# Altium schematic sheet STSPIN32.SchDoc
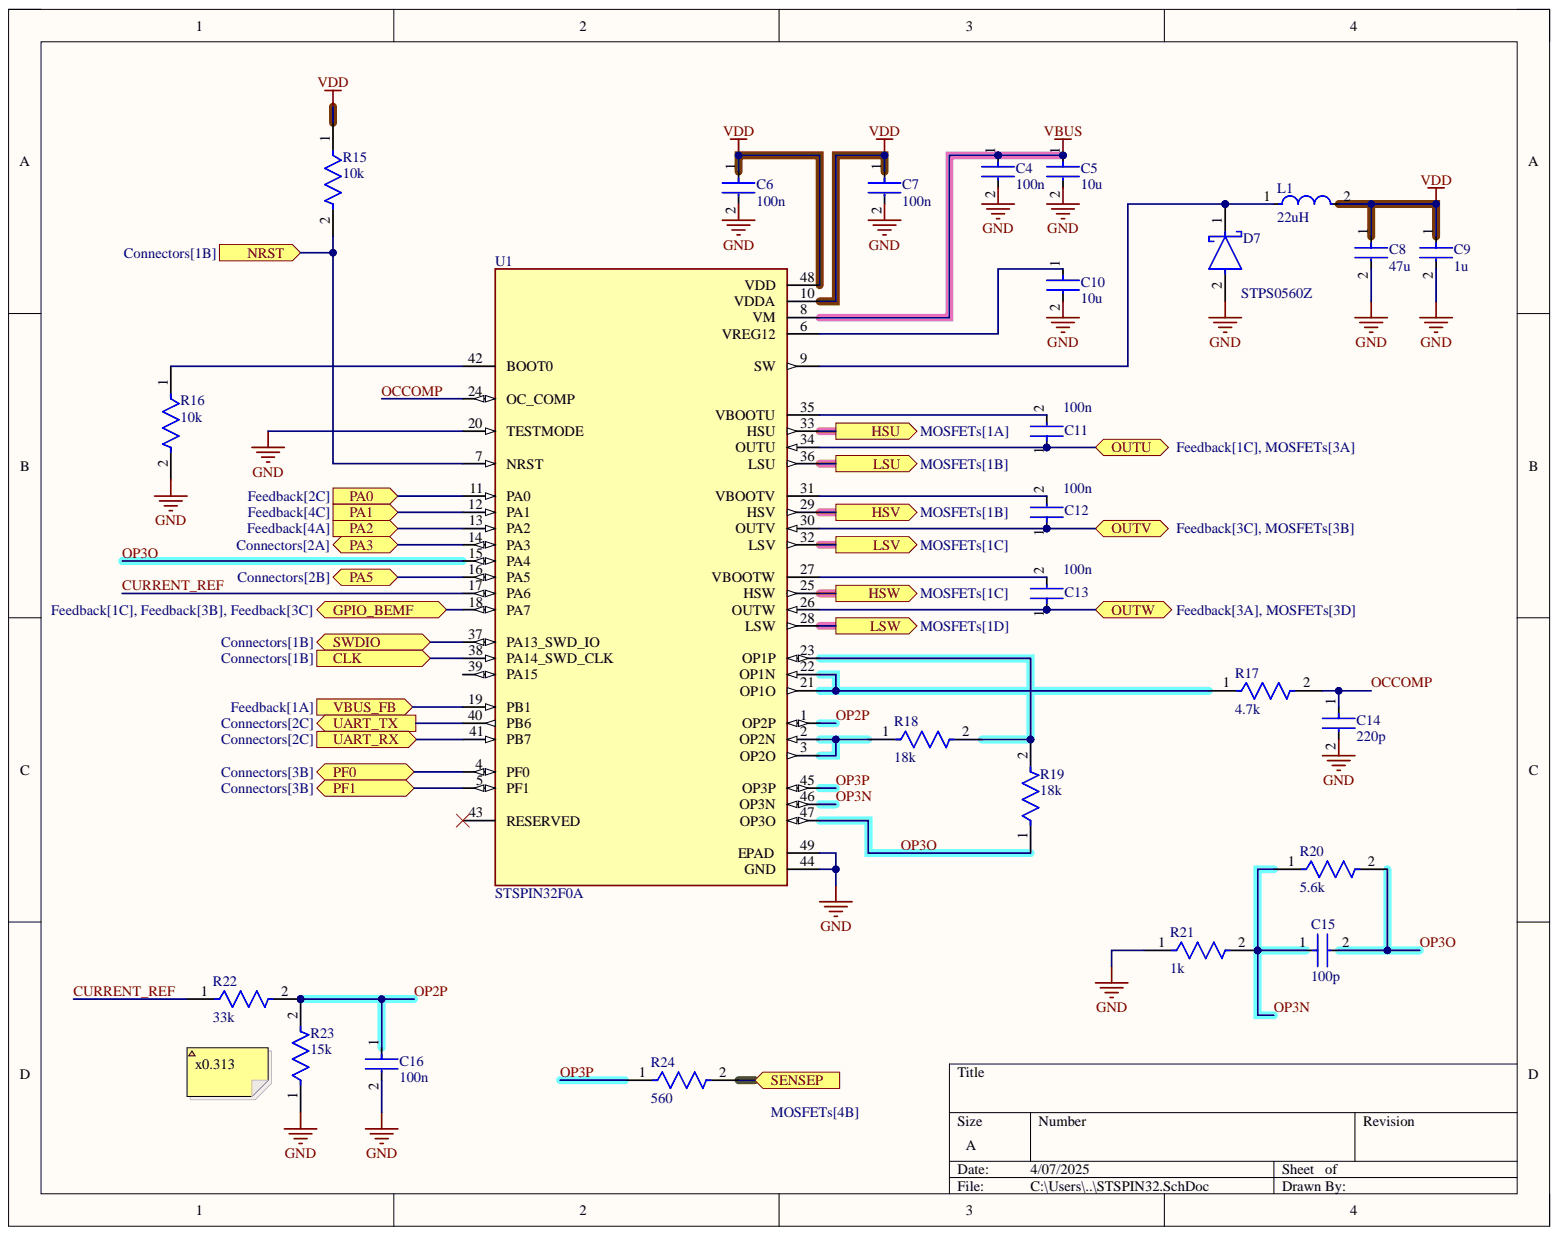
[diagram p0: STSPIN32.SchDoc]

## Parts
C4  100n  [FP-GRM188-0_1-e0_2_0_55-IPC_A]
C5  10u  [FP-GRM188-0_1-e0_2_0_55-IPC_A]
C6  100n  [FP-GRM188-0_1-e0_2_0_55-IPC_A]
C7  100n  [FP-GRM188-0_1-e0_2_0_55-IPC_A]
C8  47u  [FP-GRM188-0_1-e0_2_0_55-IPC_A]
C9  1u  [FP-GRM188-0_1-e0_2_0_55-IPC_A]
C10  10u  [FP-GRM188-0_1-e0_2_0_55-IPC_A]
C11  100n  [FP-GRM188-0_1-e0_2_0_55-IPC_A]
C12  100n  [FP-GRM188-0_1-e0_2_0_55-IPC_A]
C13  100n  [FP-GRM188-0_1-e0_2_0_55-IPC_A]
C14  220p  [FP-GRM188-0_1-e0_2_0_55-IPC_A]
C15  100p  [FP-GRM188-0_1-e0_2_0_55-IPC_A]
C16  100n  [FP-GRM188-0_1-e0_2_0_55-IPC_A]
D7  STPS0560Z  [FP-SOD-123-MFG]
L1  22uH  [FP-LQM18NN_00-IPC_C]
R15  10k  [FP-CRCW0603-e3-IPC_A]
R16  10k  [FP-CRCW0603-e3-IPC_A]
R17  4.7k  [FP-CRCW0603-e3-IPC_A]
R18  18k  [FP-CRCW0603-e3-IPC_A]
R19  18k  [FP-CRCW0603-e3-IPC_A]
R20  5.6k  [FP-CRCW0603-e3-IPC_A]
R21  1k  [FP-CRCW0603-e3-IPC_A]
R22  33k  [FP-CRCW0603-e3-IPC_A]
R23  15k  [FP-CRCW0603-e3-IPC_A]
R24  560  [FP-CRCW0603-e3-IPC_A]
U1  STSPIN32F0A  [IC_STSPIN32F0A]

## Ports
CLK, GPIO_BEMF, HSU, HSV, HSW, LSU, LSV, LSW, NRST, OUTU, OUTV, OUTW, PA0, PA1, PA2, PA3, PA5, PF0, PF1, SENSEP, SWDIO, UART_RX, UART_TX, VBUS_FB

## Nets
GND: C4.2 C5.2 C6.2 C7.2 C8.2 C9.2 C10.2 C14.2 C16.2 D7.2 R16.2 R21.1 R23.1 U1.20(TESTMODE) U1.44(GND) U1.49(EPAD)
VBUS: C4.1 C5.1 U1.8(VM)
VDD: C6.1 C7.1 C8.1 C9.1 L1.2 R15.1 U1.10(VDDA) U1.48(VDD)
CLK: U1.38(PA14_SWD_CLK) ; port CLK
CURRENT_REF: R22.1 U1.17(PA6)
GPIO_BEMF: U1.18(PA7) ; port GPIO_BEMF
HSU: U1.33(HSU) ; port HSU
HSV: U1.29(HSV) ; port HSV
HSW: U1.25(HSW) ; port HSW
LSU: U1.36(LSU) ; port LSU
LSV: U1.32(LSV) ; port LSV
LSW: U1.28(LSW) ; port LSW
NRST: R15.2 U1.7(NRST) ; port NRST
OCCOMP: C14.1 R17.2 U1.24(OC_COMP)
OP2P: C16.1 R22.2 R23.2 U1.1(OP2P)
OP3N: C15.1 R20.1 R21.2 U1.46(OP3N)
OP3O: C15.2 R19.1 R20.2 U1.15(PA4) U1.47(OP3O)
OP3P: R24.1 U1.45(OP3P)
OUTU: C11.1 U1.34(OUTU) ; port OUTU
OUTV: C12.1 U1.30(OUTV) ; port OUTV
OUTW: C13.1 U1.26(OUTW) ; port OUTW
PA0: U1.11(PA0) ; port PA0
PA1: U1.12(PA1) ; port PA1
PA2: U1.13(PA2) ; port PA2
PA3: U1.14(PA3) ; port PA3
PA5: U1.16(PA5) ; port PA5
PF0: U1.4(PF0) ; port PF0
PF1: U1.5(PF1) ; port PF1
SENSEP: R24.2 ; port SENSEP
SWDIO: U1.37(PA13_SWD_IO) ; port SWDIO
UART_RX: U1.41(PB7) ; port UART_RX
UART_TX: U1.40(PB6) ; port UART_TX
VBUS_FB: U1.19(PB1) ; port VBUS_FB
NetC10_1: C10.1 U1.6(VREG12)
NetC11_2: C11.2 U1.35(VBOOTU)
NetC12_2: C12.2 U1.31(VBOOTV)
NetC13_2: C13.2 U1.27(VBOOTW)
NetD7_1: D7.1 L1.1 U1.9(SW)
NetR16_1: R16.1 U1.42(BOOT0)
NetR17_1: R17.1 U1.21(OP1O) U1.22(OP1N)
NetR18_1: R18.1 U1.2(OP2N) U1.3(OP2O)
NetR18_2: R18.2 R19.2 U1.23(OP1P)

Not connected: U1 39(PA15) 43(RESERVED)

## Library data of the parts
C4-C16 = CMP-2006-02792-2: Chip Multilayer Ceramic Capacitors for General Purpose, 0603, 10uF, X5R, 15%, 20%, 6.3V; Capacitance: 10uF; Case Code (Imperial): 0603; Case Code (Metric): 1608; Case/Package: 0603; Component Kind: Standard; Depth: 0.8mm; Dielectric: X5R; Height: 0.8mm; Lead Free: Lead Free; Length: 1.6mm; Library Name: Altium Content Vault; Material: Ceramic; Max Operating Temperature: 85°C; Min Operating Temperature: -55°C; Mount: Surface Mount; Package Quantity: 4000; Packaging: Tape and Reel; Radiation Hardening: No; REACH SVHC: No SVHC; Termination: SMD/SMT; Thickness: 0.035inch; Tolerance: 20%; Voltage: 6.3V; Voltage Rating: 6.3V; Voltage Rating (DC): 6.3V; Width: 0.031inch
D7 = CMP-12187-000036-1: DIODE SCHOTTKY 60V 500MA SOD123; Additional Feature: FREE WHEELING DIODE; Diode Element Material: SILICON; Element Configuration: Single; Forward Voltage: 660mV; Max Forward Surge Current (Ifsm): 5.5A; Max Reverse Current: 50uA; Number of Elements: 1; Number of Terminals/Pins: 2; Package Body Material: Plastic; Polarity: Standard; Average Rectified Current: 500mA; Component Kind: Standard; Contact Plating: Tin; Current Rating: 500mA; Forward Current: 500mA; Height: 1.35mm; JESD-30 Code: R-PDSO-G2; Lead Free: Lead Free; Length: 2.85mm; Library Name: Altium Content Vault; Max Operating Temperature: 150°C; Max Repetitive Reverse Voltage (Vrrm): 60V; Max Reverse Leakage Current: 50uA; Max Reverse Voltage (DC): 60V; Max Surge Current: 5.5A; Min Operating Temperature: -65°C; Mount: Surface Mount; Number of Pins: 2; Output Current: 500mA; Package Quantity: 1; Package Shape: Rectangular; Packaging: Tape and Reel; Peak Non-Repetitive Surge Current: 5.5A; Radiation Hardening: No; REACH SVHC: No SVHC; RoHS Compliant: Yes; Series: -; Surface Mount: Yes; Technology: SCHOTTKY; Terminal Form: Gull Wing; Terminal Position: DUAL; Voltage Rating (DC): 60V; Weight: 0.01lb; Width: 1.7mm
L1 = CMP-06042-016797-1: Multilayer Type Inductor for General Circuits, 0603 (1608), 120nH, 10%, 0.5Ω, 50mA; Core Material: Ferrite; DC Resistance (DCR): 500mR; Inductor Type: MONOLITHIC; Q Factor: 15; Self Resonant Frequency: 205MHz; Shielding: Shielded; Test Frequency: 25MHz; Case Code (Imperial): 0603; Case Code (Metric): 1608; Case/Package: 0603; Component Kind: Standard; Current Rating: 50mA; DC Current: 50mA; Depth: 0.95mm; Height: 0.8mm; Height - Seated (Max): 0.035inch; Inductance: 120nH; Inductance Tolerance: 10%; Length: 1.6mm; Library Name: Altium Content Vault; Max DC Current: 50mA; Max Operating Temperature: 85°C; Military Standard: Not; Min Operating Temperature: -40°C; Mount: Surface Mount; Number of Pins: 2; Package Quantity: 4000; Packaging: Tape and Reel; Radiation Hardening: No; REACH SVHC: No SVHC; RoHS Compliant: Yes; Series: LQM18N; Termination: SMD/SMT; Tolerance: 10%; Width: 0.8mm
R15-R24 = CMP-2000-03845-2: RES Thick Film, 10Ω, 1%, 0.1W, 100ppm/°C, 0603; Max Power Dissipation: 100mW; Resistance: 10R; Voltage Rating: 75V; Case Code (Imperial): 0603; Case Code (Metric): 1608; Case/Package: 0603; Component Kind: Standard; Composition: Thick Film; Contact Plating: Tin; Depth: 0.85mm; Features: Automotive AEC-Q200; Height: 0.45mm; Lead Free: Lead Free; Length: 1.55mm; Library Name: Altium Content Vault; Max Operating Temperature: 155°C; Military Standard: Not; Min Operating Temperature: -55°C; Mount: Surface Mount; Number of Pins: 2; Number of Terminations: 2; Package Quantity: 10; Packaging: Tape and Reel; Power Rating: 100mW; Temperature Coefficient: 100ppm/°C; Termination: SMD/SMT; Tolerance: 1%; Voltage Rating (DC): 75V; Weight: 7.1E-05oz; Width: 0.033inch
U1 = CMP-002-000000-1: IC BLDC CTLR STM32 48VFQFPN; MF: STMicroelectronics; Check_prices: https://www.snapeda.com/parts/STSPIN32F0A/STMicroelectronics/view-part/?ref=eda; Component Kind: Standard; Component Type: Standard; Design Item ID: STSPIN32F0A; Library Name: My_projects; MP: STSPIN32F0A; Package: VFQFPN-48 STMicroelectronics; Pin Count: 49; SnapEDA_Link: https://www.snapeda.com/parts/STSPIN32F0A/STMicroelectronics/view-part/?ref=snap; Source: STSPIN32F0A.SchLib
